# Altium schematic sheet 03.ETC.SchDoc
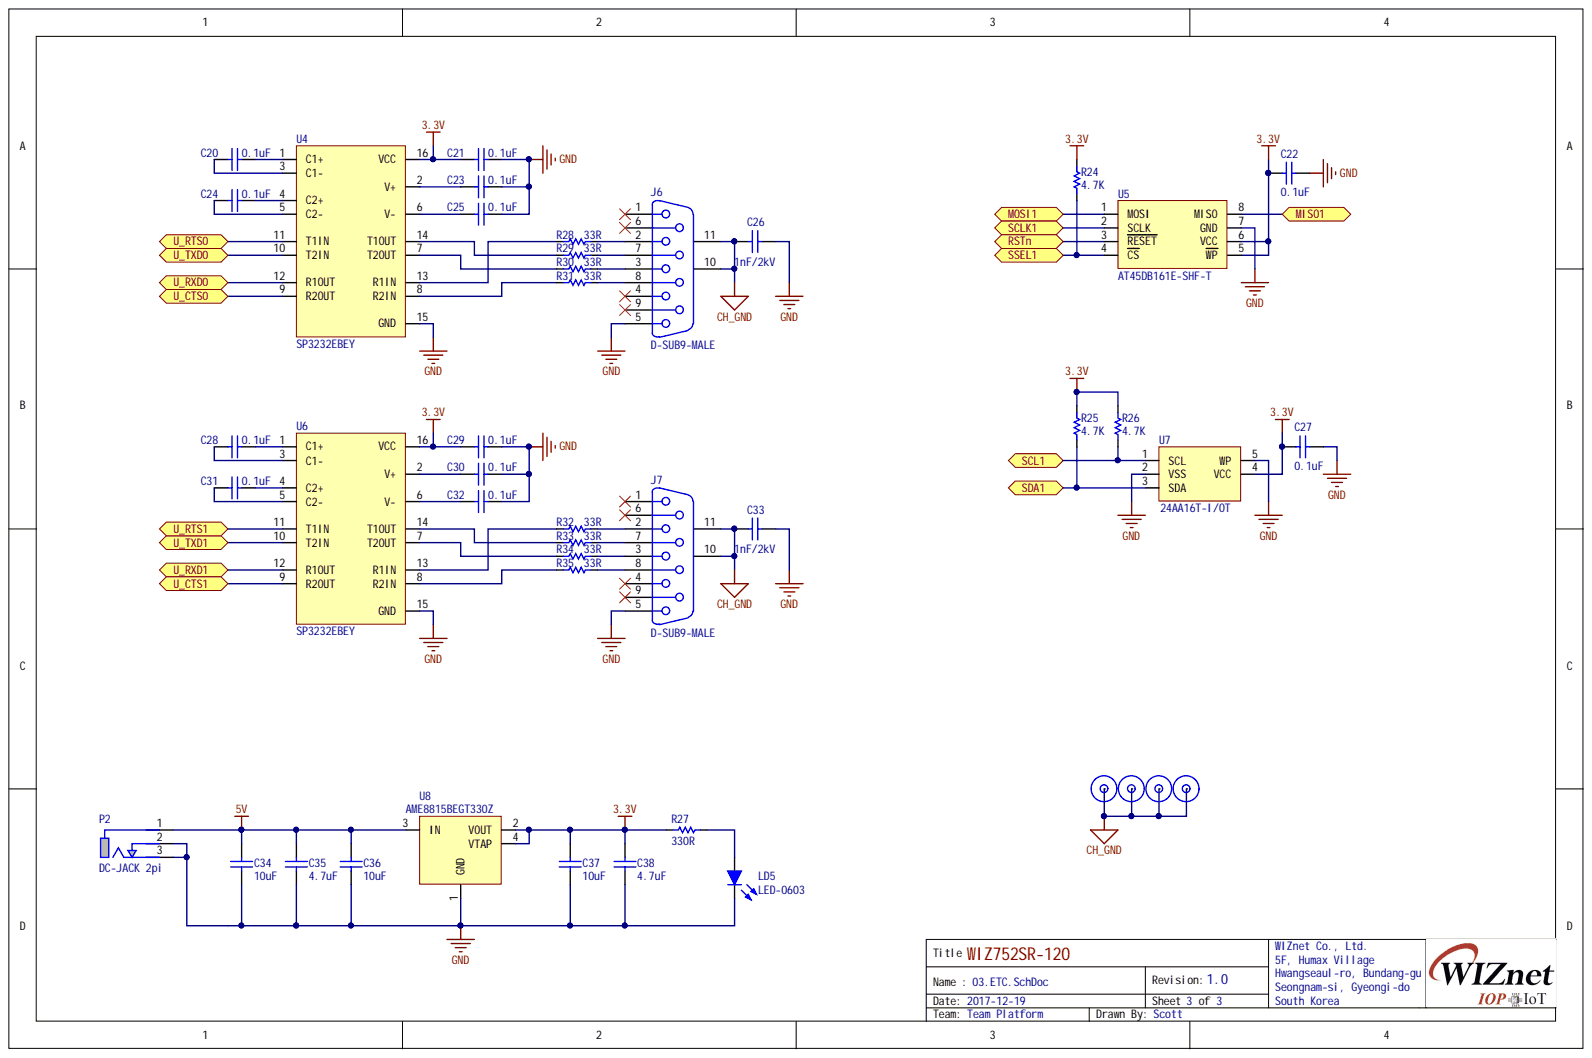
[diagram p0: 03.ETC.SchDoc]

## Parts
C20  0.1uF  [CAP-0603]
C21  0.1uF  [CAP-0603]
C22  0.1uF  [CAP-0603]
C23  0.1uF  [CAP-0603]
C24  0.1uF  [CAP-0603]
C25  0.1uF  [CAP-0603]
C26  1nF/2kV  [CAP-1808]
C27  0.1uF  [CAP-0603]
C28  0.1uF  [CAP-0603]
C29  0.1uF  [CAP-0603]
C30  0.1uF  [CAP-0603]
C31  0.1uF  [CAP-0603]
C32  0.1uF  [CAP-0603]
C33  1nF/2kV  [CAP-1808]
C34  10uF  [CAP-1206]
C35  4.7uF  [CAP-1206]
C36  10uF  [CAP-1206]
C37  10uF  [CAP-1206]
C38  4.7uF  [CAP-1206]
H1  PCB Hole  [HOLE-3.0/7.0]  (value not drawn)
H2  PCB Hole  [HOLE-3.0/7.0]  (value not drawn)
H3  PCB Hole  [HOLE-3.0/7.0]  (value not drawn)
H4  PCB Hole  [HOLE-3.0/7.0]  (value not drawn)
J6  D-SUB9-MALE  [D-SUB9-MALE]
J7  D-SUB9-MALE  [D-SUB9-MALE]
LD5  LED-0603  [LED-0603-RED]
P2  DC-JACK 2pi  [DC-JACK 2pi]
R24  4.7K  [RES-0603]
R25  4.7K  [RES-0603]
R26  4.7K  [RES-0603]
R27  330R  [RES-0603]
R28  33R  [RES-0603]
R29  33R  [RES-0603]
R30  33R  [RES-0603]
R31  33R  [RES-0603]
R32  33R  [RES-0603]
R33  33R  [RES-0603]
R34  33R  [RES-0603]
R35  33R  [RES-0603]
U4  SP3232EBEY  [TSSOP16(0.65/6.4)]
U5  AT45DB161E-SHF-T  [SO-08-EIAJ]
U6  SP3232EBEY  [TSSOP16(0.65/6.4)]
U7  24AA16T-I/OT  [SOT-23-5L]
U8  AME8815BEGT330Z  [SOT-223]

## Ports
MISO1, MOSI1, RSTn, SCL1, SCLK1, SDA1, SSEL1, U_CTS0, U_CTS1, U_RTS0, U_RTS1, U_RXD0, U_RXD1, U_TXD0, U_TXD1

## Nets
3.3V: C21.2 C22.2 C27.2 C29.2 C37.2 C38.2 R24.2 R25.2 R26.2 R27.2 U4.16(VCC) U5.5(WP) U5.6(VCC) U6.16(VCC) U7.4(VCC) U8.2(VOUT) U8.4(VTAP)
5V: C34.2 C35.2 C36.2 P2.1 U8.3(IN)
CH_GND: C26.1 C33.1 H1.1 H2.1 H3.1 H4.1 J6.10 J6.11 J7.10 J7.11
GND: C21.1 C22.1 C23.1 C25.1 C26.2 C27.1 C29.1 C30.1 C32.1 C33.2 C34.1 C35.1 C36.1 C37.1 C38.1 J6.5 J7.5 LD5.2 P2.2 P2.3 U4.15(GND) U5.7(GND) U6.15(GND) U7.2(VSS) U7.5(WP) U8.1(GND)
MISO1: U5.8(MISO) ; port MISO1
MOSI1: U5.1(MOSI) ; port MOSI1
RSTn: U5.3(RESET) ; port RSTn
SCL1: R26.1 U7.1(SCL) ; port SCL1
SCLK1: U5.2(SCLK) ; port SCLK1
SDA1: R25.1 U7.3(SDA) ; port SDA1
SSEL1: R24.1 U5.4(CS) ; port SSEL1
U_CTS0: U4.9(R2OUT) ; port U_CTS0
U_CTS1: U6.9(R2OUT) ; port U_CTS1
U_RTS0: U4.11(T1IN) ; port U_RTS0
U_RTS1: U6.11(T1IN) ; port U_RTS1
U_RXD0: U4.12(R1OUT) ; port U_RXD0
U_RXD1: U6.12(R1OUT) ; port U_RXD1
U_TXD0: U4.10(T2IN) ; port U_TXD0
U_TXD1: U6.10(T2IN) ; port U_TXD1
NetC20_1: C20.1 U4.1(C1+)
NetC20_2: C20.2 U4.3(C1-)
NetC23_2: C23.2 U4.2(V+)
NetC24_1: C24.1 U4.4(C2+)
NetC24_2: C24.2 U4.5(C2-)
NetC25_2: C25.2 U4.6(V-)
NetC28_1: C28.1 U6.1(C1+)
NetC28_2: C28.2 U6.3(C1-)
NetC30_2: C30.2 U6.2(V+)
NetC31_1: C31.1 U6.4(C2+)
NetC31_2: C31.2 U6.5(C2-)
NetC32_2: C32.2 U6.6(V-)
NetJ6_2: J6.2 R28.2
NetJ6_3: J6.3 R30.2
NetJ6_7: J6.7 R29.2
NetJ6_8: J6.8 R31.2
NetJ7_2: J7.2 R32.2
NetJ7_3: J7.3 R34.2
NetJ7_7: J7.7 R33.2
NetJ7_8: J7.8 R35.2
NetLD5_1: LD5.1 R27.1
NetR28_1: R28.1 U4.13(R1IN)
NetR29_1: R29.1 U4.14(T1OUT)
NetR30_1: R30.1 U4.7(T2OUT)
NetR31_1: R31.1 U4.8(R2IN)
NetR32_1: R32.1 U6.13(R1IN)
NetR33_1: R33.1 U6.14(T1OUT)
NetR34_1: R34.1 U6.7(T2OUT)
NetR35_1: R35.1 U6.8(R2IN)

Not connected: J6 1 4 6 9; J7 1 4 6 9

## Library data of the parts
C20-C25 C27-C32 = CAP-0603: Ceramic CAP 0603(1608); Component Kind: Standard; Library Name: Scott_lib.IntLib
C26 C33 = CAP-1808: Ceramic CAP 1808(4520); Component Kind: Standard; Library Name: Scott_lib.IntLib
C34-C38 = CAP-1206: Ceramic CAP 1206(3216); Component Kind: Standard; Library Name: Scott_lib.IntLib
H1-H4 = PCB Hole: PCB Supporter Hole; Component Kind: Standard; Library Name: Scott_lib.IntLib
J6 J7 = D-SUB9-MALE: D-SUB-9, MALE,  Right Angle; Gender: Receptacle; PackageDocument: O; Positions: 9; Component Kind: Standard; DatasheetDocument: 1-Sep-1999; LatestRevisionDate: 17-Jul-2002; LatestRevisionNote: Re-released for DXP Platform.; Orientation: Right Angle; PackageReference: 788750; Published: 15-Jun-2001; Library Name: Scott_lib.IntLib; Publisher: Altium Limited
R24-R35 = RES-0603: RES0603; Component Kind: Standard; Library Name: Scott_lib.IntLib
U4 U6 = SP3232EBEY: True +3.0V to +5.5V RS-232 Transceivers; Component Kind: Standard; Library Name: Scott_lib.IntLib
U5 = AT45DB161E-SHF-T: 16-Mbit DataFlash, 2.3V or 2.5V Minimum SPI Serial Flash Memory; Component Kind: Standard; Library Name: Edward.IntLib
U7 = 24AAxxT-i-OT: SOT-23; Component Kind: Standard; Library Name: 24AAxxT-OT.SchLib
U8 = AME8815BEGT330Z: 1.5A CMOS LDO; Component Kind: Standard; Library Name: Scott_lib.IntLib
LD5 = LED-0603: Component Kind: Standard; Description: LED-0603; Library Name: Scott_lib.IntLib
P2 = DC-JACK 2pi: Component Kind: Standard; Description: DC-JACK 2pi; Library Name: Scott_lib.IntLib
